# Altium schematic sheet Kızılötesialıcı.SchDoc
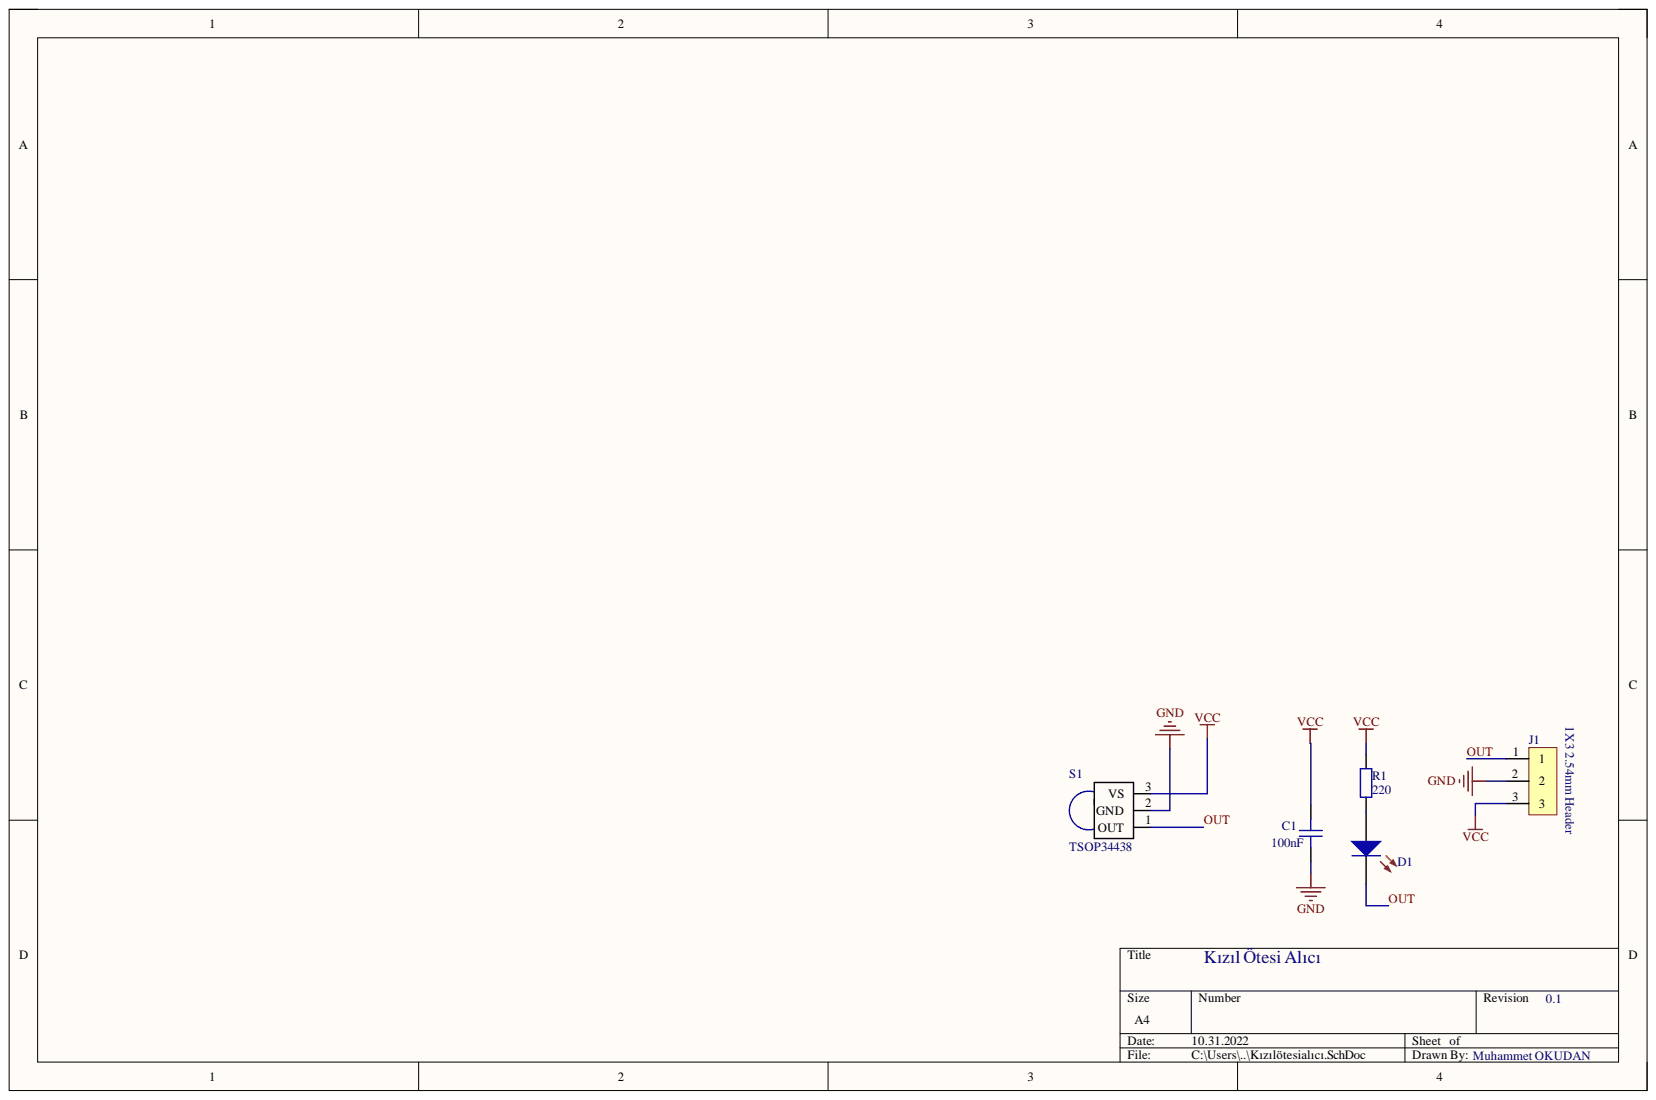
[diagram p0: Kızılötesialıcı.SchDoc]

## Parts
C1  100nF  [0603 CAP]
D1  LED KIRMIZI  [LED 1206 KIRMIZI]  (value not drawn)
J1  1X3 2.54mm Header  [1X3 2.54mm HEADER]
R1  220  [0603 RES]
S1  TSOP34438  [TSOP34438 KIZILÖTESİ VERİCİ]

## Nets
GND: C1.1 J1.2 S1.2
VCC: C1.2 J1.3 R1.2 S1.3
OUT: D1.2 J1.1 S1.1
NetD1_1: D1.1 R1.1

## Library data of the parts
C1 = 0603 cap: 0603 SMD CAP; Component Kind: Standard; Library Name: kızılötesi_verici.SchLib
D1 = LED KIRMIZI: 1206 SMD KIRMIZI LED; Component Kind: Standard; Library Name: kızılötesi_verici.SchLib
R1 = 0603 res: 0603 SMD RES; Component Kind: Standard; Library Name: Kızılötesialıcı.SchLib
S1 = TSOP34438: Kızılötesi verici sensör TSOP34438; Component Kind: Standard; Library Name: kızılötesi_verici.SchLib
J1 = 1X3 2.54mm Header: Component Kind: Standard; Library Name: kızılötesi_verici.SchLib
